# Altium schematic sheet [5]fame.SchDoc
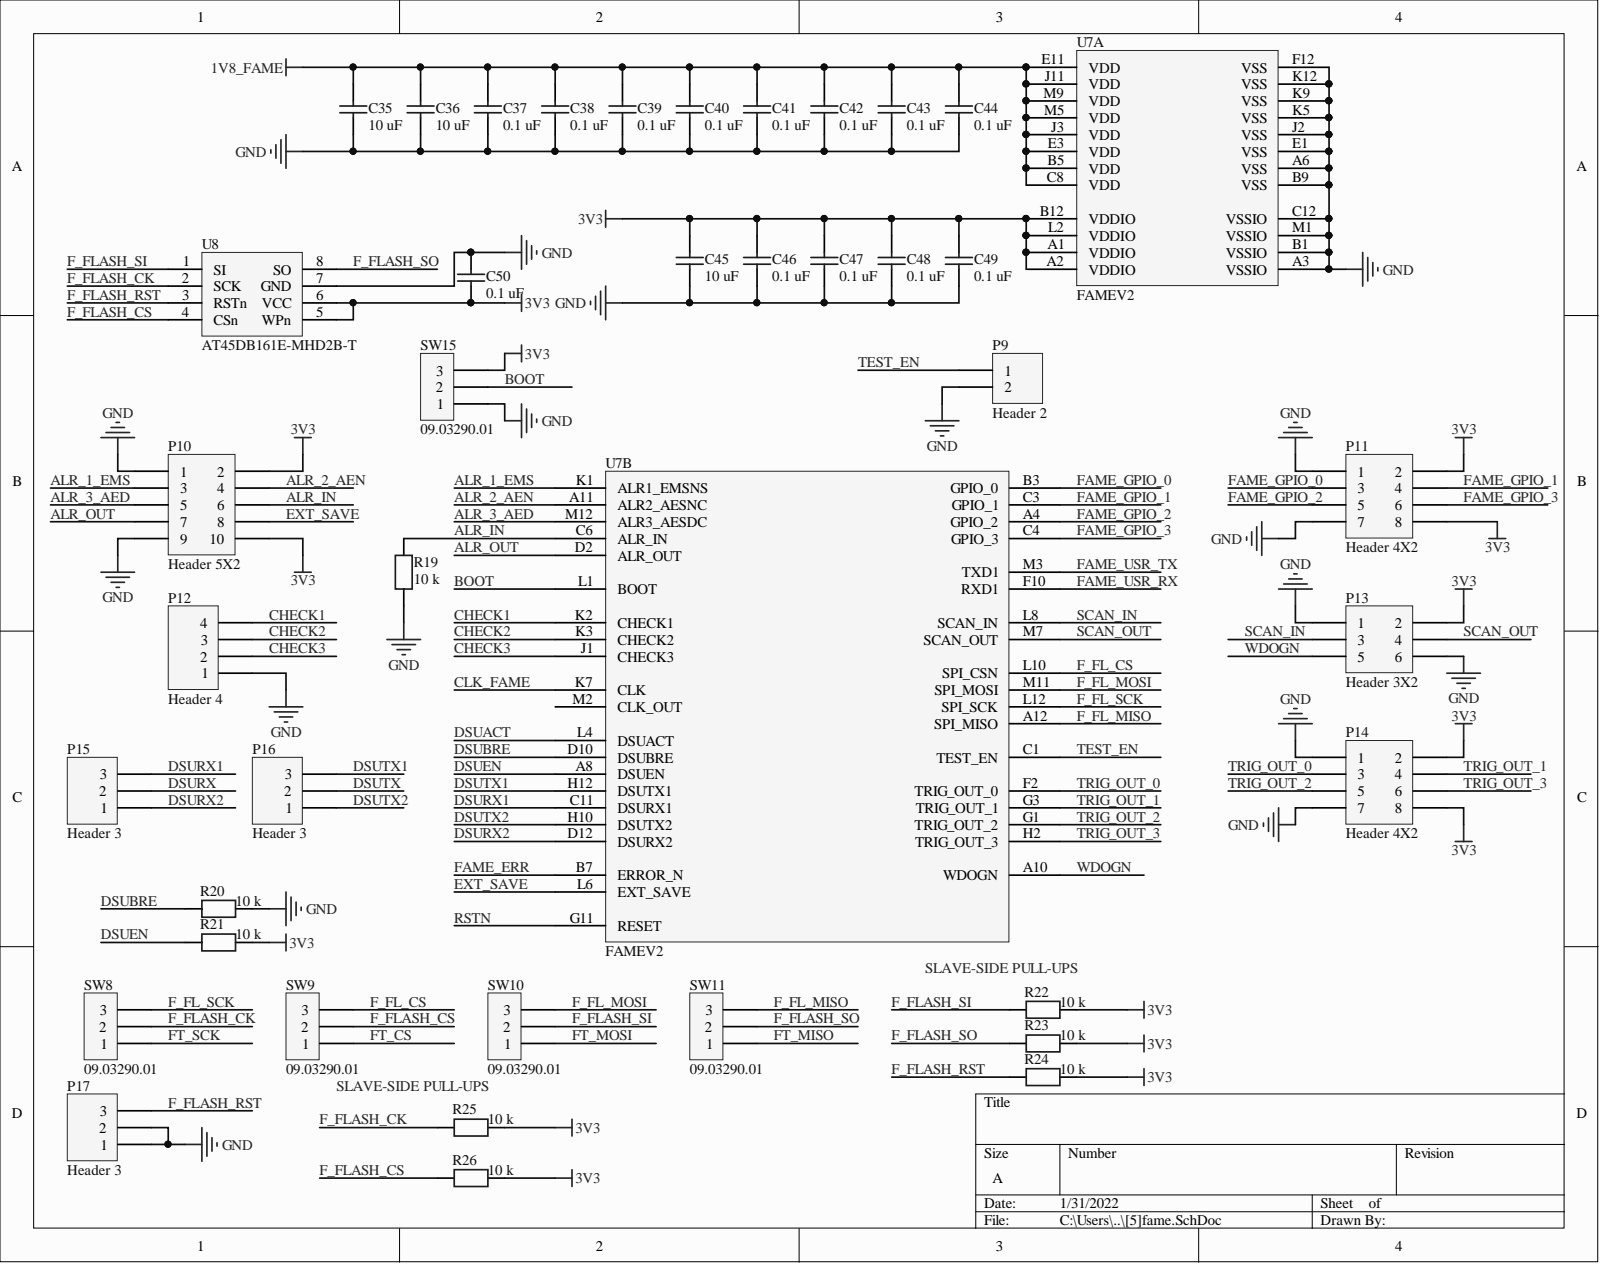
[diagram p0: [5]fame.SchDoc]

## Parts
C35  value 10 uF  [0603-CAP-ASM]  (hidden comment: Cap)
C36  value 10 uF  [0603-CAP-ASM]  (hidden comment: Cap)
C37  value 0.1 uF  [0402-CAP-ASM]  (hidden comment: Cap)
C38  value 0.1 uF  [0402-CAP-ASM]  (hidden comment: Cap)
C39  value 0.1 uF  [0402-CAP-ASM]  (hidden comment: Cap)
C40  value 0.1 uF  [0402-CAP-ASM]  (hidden comment: Cap)
C41  value 0.1 uF  [0402-CAP-ASM]  (hidden comment: Cap)
C42  value 0.1 uF  [0402-CAP-ASM]  (hidden comment: Cap)
C43  value 0.1 uF  [0402-CAP-ASM]  (hidden comment: Cap)
C44  value 0.1 uF  [0402-CAP-ASM]  (hidden comment: Cap)
C45  value 10 uF  [0603-CAP-ASM]  (hidden comment: Cap)
C46  value 0.1 uF  [0402-CAP-ASM]  (hidden comment: Cap)
C47  value 0.1 uF  [0402-CAP-ASM]  (hidden comment: Cap)
C48  value 0.1 uF  [0402-CAP-ASM]  (hidden comment: Cap)
C49  value 0.1 uF  [0402-CAP-ASM]  (hidden comment: Cap)
C50  value 0.1 uF  [0402-CAP-ASM]  (hidden comment: Cap)
P9  Header 2  [header 2]
P10  Header 5X2  [HDR_2x5_2R54_V_M]
P11  Header 4X2  [HDR_2x4_2R54_V_M]
P12  Header 4  [HDR1X4]
P13  Header 3X2  [HDR_2x3_2R54_V_M]
P14  Header 4X2  [HDR_2x4_2R54_V_M]
P15  Header 3  [Header 1x3 male]
P16  Header 3  [Header 1x3 male]
P17  Header 3  [Header 1x3 male]
R19  value 10 k  [0402-RES-ASM]  (hidden comment: res)
R20  value 10 k  [0402-RES-ASM]  (hidden comment: res)
R21  value 10 k  [0402-RES-ASM]  (hidden comment: res)
R22  value 10 k  [0402-RES-ASM]  (hidden comment: res)
R23  value 10 k  [0402-RES-ASM]  (hidden comment: res)
R24  value 10 k  [0402-RES-ASM]  (hidden comment: res)
R25  value 10 k  [0402-RES-ASM]  (hidden comment: res)
R26  value 10 k  [0402-RES-ASM]  (hidden comment: res)
SW8  09.03290.01  [09.03290.01]
SW9  09.03290.01  [09.03290.01]
SW10  09.03290.01  [09.03290.01]
SW11  09.03290.01  [09.03290.01]
SW15  09.03290.01  [09.03290.01]
U7  FAMEV2  [pga13x13]  (parts A,B)
U8  AT45DB161E-MHD2B-T  [udfn-8]

## Nets
1V8_FAME: C35.2 C36.2 C37.2 C38.2 C39.2 C40.2 C41.2 C42.2 C43.2 C44.2 U7.B5(VDD) U7.C8(VDD) U7.E3(VDD) U7.E11(VDD) U7.J3(VDD) U7.J11(VDD) U7.M5(VDD) U7.M9(VDD)
3V3: C45.2 C46.2 C47.2 C48.2 C49.2 C50.1 P10.2 P10.10 P11.2 P11.8 P13.2 P14.2 P14.8 R21.2 R22.2 R23.2 R24.2 R25.2 R26.2 SW15.3 U7.A1(VDDIO) U7.A2(VDDIO) U7.B12(VDDIO) U7.L2(VDDIO) U8.5(WPn) U8.6(VCC)
GND: C35.1 C36.1 C37.1 C38.1 C39.1 C40.1 C41.1 C42.1 C43.1 C44.1 C45.1 C46.1 C47.1 C48.1 C49.1 C50.2 P9.2 P10.1 P10.9 P11.1 P11.7 P12.1 P13.1 P13.6 P14.1 P14.7 P17.1 P17.2 R19.1 R20.2 SW15.1 U7.A3(VSSIO) U7.A6(VSS) U7.B1(VSSIO) U7.B9(VSS) U7.C12(VSSIO) U7.E1(VSS) U7.F12(VSS) U7.J2(VSS) U7.K5(VSS) U7.K9(VSS) U7.K12(VSS) U7.M1(VSSIO) U8.7(GND)
ALR_1_EMS: P10.3 U7.K1(ALR1_EMSNS)
ALR_2_AEN: P10.4 U7.A11(ALR2_AESNC)
ALR_3_AED: P10.5 U7.M12(ALR3_AESDC)
ALR_IN: P10.6 R19.2 U7.C6(ALR_IN)
ALR_OUT: P10.7 U7.D2(ALR_OUT)
BOOT: SW15.2 U7.L1(BOOT)
CHECK1: P12.4 U7.K2(CHECK1)
CHECK2: P12.3 U7.K3(CHECK2)
CHECK3: P12.2 U7.J1(CHECK3)
CLK_FAME: U7.K7(CLK)
DSUACT: U7.L4(DSUACT)
DSUBRE: R20.1 U7.D10(DSUBRE)
DSUEN: R21.1 U7.A8(DSUEN)
DSURX: P15.2
DSURX1: P15.3 U7.C11(DSURX1)
DSURX2: P15.1 U7.D12(DSURX2)
DSUTX: P16.2
DSUTX1: P16.3 U7.H12(DSUTX1)
DSUTX2: P16.1 U7.H10(DSUTX2)
EXT_SAVE: P10.8 U7.L6(EXT_SAVE)
F_FL_CS: SW9.3 U7.L10(SPI_CSN)
F_FL_MISO: SW11.3 U7.A12(SPI_MISO)
F_FL_MOSI: SW10.3 U7.M11(SPI_MOSI)
F_FL_SCK: SW8.3 U7.L12(SPI_SCK)
F_FLASH_CK: R25.1 SW8.2 U8.2(SCK)
F_FLASH_CS: R26.1 SW9.2 U8.4(CSn)
F_FLASH_RST: P17.3 R24.1 U8.3(RSTn)
F_FLASH_SI: R22.1 SW10.2 U8.1(SI)
F_FLASH_SO: R23.1 SW11.2 U8.8(SO)
FAME_ERR: U7.B7(ERROR_N)
FAME_GPIO_0: P11.3 U7.B3(GPIO_0)
FAME_GPIO_1: P11.4 U7.C3(GPIO_1)
FAME_GPIO_2: P11.5 U7.A4(GPIO_2)
FAME_GPIO_3: P11.6 U7.C4(GPIO_3)
FAME_USR_RX: U7.F10(RXD1)
FAME_USR_TX: U7.M3(TXD1)
FT_CS: SW9.1
FT_MISO: SW11.1
FT_MOSI: SW10.1
FT_SCK: SW8.1
RSTN: U7.G11(RESET)
SCAN_IN: P13.3 U7.L8(SCAN_IN)
SCAN_OUT: P13.4 U7.M7(SCAN_OUT)
TEST_EN: P9.1 U7.C1(TEST_EN)
TRIG_OUT_0: P14.3 U7.F2(TRIG_OUT_0)
TRIG_OUT_1: P14.4 U7.G3(TRIG_OUT_1)
TRIG_OUT_2: P14.5 U7.G1(TRIG_OUT_2)
TRIG_OUT_3: P14.6 U7.H2(TRIG_OUT_3)
WDOGN: P13.5 U7.A10(WDOGN)

Not connected: U7 M2(CLK_OUT)

## Library data of the parts
C35 C36 C45 = Cap: CAP CER 10UF 10V X5R 0603; Component Kind: Standard; Library Name: Miscellaneous Devices.IntLib; Supplier Part Number: 1276-1192-1-ND
C37-C44 C46-C50 = Cap: CAP CER 0.1UF 10V X5R 0402; Component Kind: Standard; Library Name: Miscellaneous Devices.IntLib; Supplier Part Number: 587-1227-1-ND
P9 = Header 2: CONN HEADER VERT 2POS; Component Kind: Standard; Library Name: Miscellaneous Connectors.IntLib; Supplier Part Number: SAM1030-01-ND
P10 = Header 5X2: CONN HEADER VERT 10POS 2.54MM; Component Kind: Standard; Library Name: Miscellaneous Connectors.IntLib; Supplier Part Number: SAM1028-05-ND
P11 P14 = Header 4X2: CONN HEADER VERT 8POS 2.54MM; Component Kind: Standard; Library Name: Miscellaneous Connectors.IntLib; Supplier Part Number: SAM8996-ND
P12 = Header 4: CONN HEADER VERT 4POS 2.54MM; Component Kind: Standard; Library Name: Miscellaneous Connectors.IntLib; Supplier Part Number: SAM1031-04-ND
P13 = Header 3X2: CONN HEADER VERT 6POS 2.54MM; Component Kind: Standard; Library Name: Miscellaneous Connectors.IntLib; Supplier Part Number: SAM10846-ND
P15-P17 = Header 3: CONN HEADER VERT 3POS 2.54MM; Component Kind: Standard; Library Name: Miscellaneous Connectors.IntLib; Supplier Part Number: SAM1031-03-ND
R19-R26 = RESISTOR: RES SMD 10K OHM 5% 1/16W 0402; Component Kind: Standard; Library Name: Mylib..SchLib; Supplier Part Number: 311-10KJRCT-ND
SW8-SW11 SW15 = 09.03290.01: 1K2 SW CHANGE OVER RAISED ACT; Component Kind: Standard; Library Name: Mylib..SchLib; Supplier Part Number: 1948-1087-ND
U8 = AT45DB161E-MHF-T: IC FLASH 16MBIT SPI 85MHZ 8UDFN; Component Kind: Standard; Library Name: Mylib..SchLib; Supplier Part Number: 1265-1129-1-ND
U7 = FAMEV2: Component Kind: Standard; Description: FAMEV2; Library Name: Mylib..SchLib
